# Altium schematic sheet POWER.SchDoc(POWER)
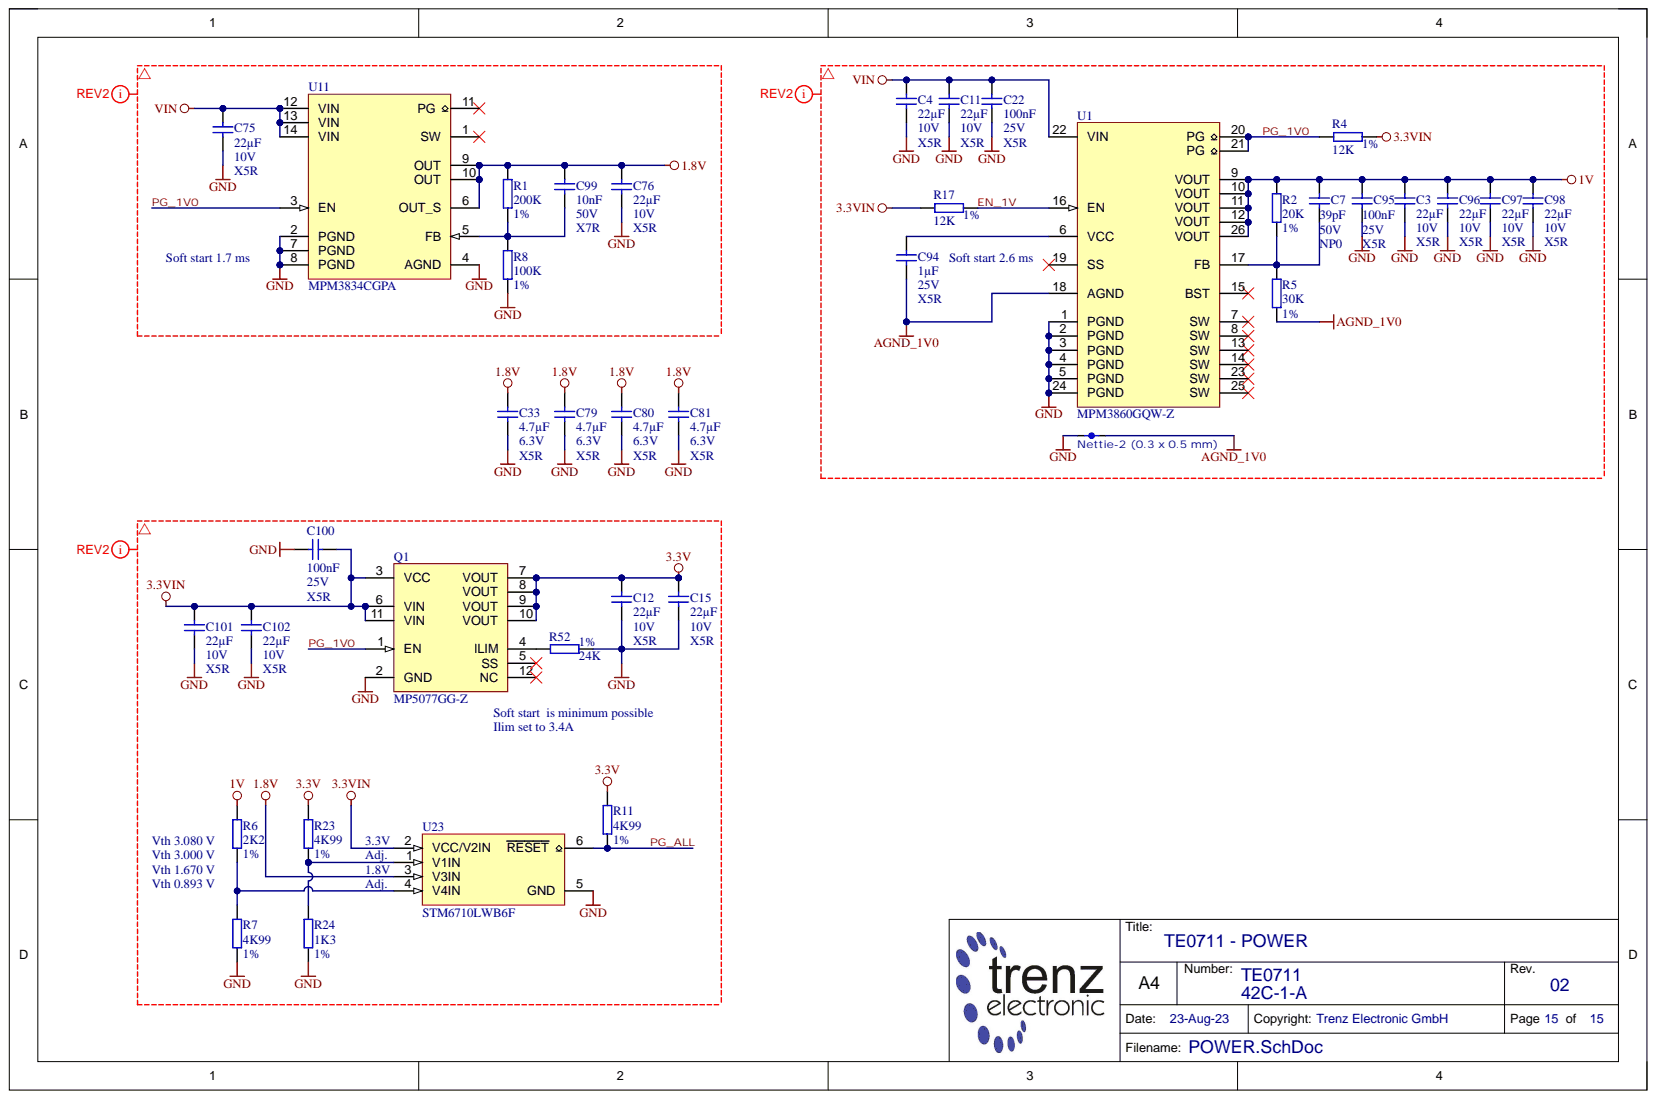
[diagram p0: POWER.SchDoc]

## Parts
C3  22µF  Technology: X5R  Voltage: 10V  [CAPC1608X90N_V]
C4  22µF  Technology: X5R  Voltage: 10V  [CAPC1608X90N_V]
C7  39pF  Technology: NP0  Voltage: 50V  [CAPC1005X55N_V]
C11  22µF  Technology: X5R  Voltage: 10V  [CAPC1608X90N_V]
C12  22µF  Technology: X5R  Voltage: 10V  [CAPC1608X90N_V]
C15  22µF  Technology: X5R  Voltage: 10V  [CAPC1608X90N_V]
C22  100nF  Technology: X5R  Voltage: 25V  [CAPC1005X55N_V]
C33  4.7µF  Technology: X5R  Voltage: 6.3V  [CAPC1005X55N_V]
C75  22µF  Technology: X5R  Voltage: 10V  [CAPC1608X90N_V]
C76  22µF  Technology: X5R  Voltage: 10V  [CAPC1608X90N_V]
C79  4.7µF  Technology: X5R  Voltage: 6.3V  [CAPC1005X55N_V]
C80  4.7µF  Technology: X5R  Voltage: 6.3V  [CAPC1005X55N_V]
C81  4.7µF  Technology: X5R  Voltage: 6.3V  [CAPC1005X55N_V]
C94  1µF  Technology: X5R  Voltage: 25V  [CAPC1005X55N_V]
C95  100nF  Technology: X5R  Voltage: 25V  [CAPC1005X55N_V]
C96  22µF  Technology: X5R  Voltage: 10V  [CAPC1608X90N_V]
C97  22µF  Technology: X5R  Voltage: 10V  [CAPC1608X90N_V]
C98  22µF  Technology: X5R  Voltage: 10V  [CAPC1608X90N_V]
C99  10nF  Technology: X7R  Voltage: 50V  [CAPC1005X55N_V]
C100  100nF  Voltage: 25V  [CAPC1005X55N_V]
C101  22µF  Technology: X5R  Voltage: 10V  [CAPC1608X90N_V]
C102  22µF  Technology: X5R  Voltage: 10V  [CAPC1608X90N_V]
Q1  MP5077GG-Z  [QFN40P200X200X100-12N]
R1  200K  Tolerance: 1%  [RESC1005X40N_V]
R2  20K  Tolerance: 1%  [RESC1005X40N_V]
R4  12K  Tolerance: 1%  [RESC1005X40N_V]
R5  30K  Tolerance: 1%  [RESC1005X40N_V]
R6  2K2  Tolerance: 1%  [RESC1005X40N_V]
R7  4K99  Tolerance: 1%  [RESC1005X40N_V]
R8  100K  Tolerance: 1%  [RESC1005X40N_V]
R11  4K99  Tolerance: 1%  [RESC1005X40N_V]
R17  12K  Tolerance: 1%  [RESC1005X40N_V]
R23  4K99  Tolerance: 1%  [RESC1005X40N_V]
R24  1K3  Tolerance: 1%  [RESC1005X40N_V]
R52  24K  Tolerance: 1%  [RESC1005X40N_V]
U1  MPM3860GQW-Z  [MPM3650]
U11  MPM3834CGPA  [MPM3834C]
U23  STM6710LWB6F  [SOT95P280X145-6N]
V1  Nettie-2 (0.3 x 0.5 mm)  [Nettie-2-Bar-0.3-0.5mm]

## Nets
1.8V: C33.1 C76.1 C79.1 C80.1 C81.1 C99.1 R1.1 U11.6(OUT_S) U11.9(OUT) U11.10(OUT) U23.3(V3IN)
1V: C3.1 C7.1 C95.1 C96.1 C97.1 C98.1 R2.1 R6.1 U1.9(VOUT) U1.10(VOUT) U1.11(VOUT) U1.12(VOUT) U1.26(VOUT)
3.3V: C12.1 C15.1 Q1.7(VOUT) Q1.8(VOUT) Q1.9(VOUT) Q1.10(VOUT) R11.1 R23.1
3.3VIN: C100.2 C101.1 C102.1 Q1.3(VCC) Q1.6(VIN) Q1.11(VIN) R4.2 R17.1 U23.2(VCC/V2IN)
AGND_1V0: C94.2 R5.2 U1.18(AGND) V1.2
GND: C3.2 C4.2 C11.2 C12.2 C15.2 C22.2 C33.2 C75.2 C76.2 C79.2 C80.2 C81.2 C95.2 C96.2 C97.2 C98.2 C100.1 C101.2 C102.2 Q1.2(GND) R7.2 R8.2 R24.2 R52.2 U1.1(PGND) U1.2(PGND) U1.3(PGND) U1.4(PGND) U1.5(PGND) U1.24(PGND) U11.2(PGND) U11.4(AGND) U11.7(PGND) U11.8(PGND) U23.5(GND) V1.1
VIN: C4.1 C11.1 C22.1 C75.1 U1.22(VIN) U11.12(VIN) U11.13(VIN) U11.14(VIN)
EN_1V: R17.2 U1.16(EN)
PG_1V0: Q1.1(EN) R4.1 U1.20(PG) U1.21(PG) U11.3(EN)
PG_ALL: R11.2 U23.6(RESET)
NetC7_2: C7.2 R2.2 R5.1 U1.17(FB)
NetC94_1: C94.1 U1.6(VCC)
NetC99_2: C99.2 R1.2 R8.1 U11.5(FB)
NetQ1_4: Q1.4(ILIM) R52.1
NetR6_2: R6.2 R7.1 U23.4(V4IN)
NetR23_2: R23.2 R24.1 U23.1(V1IN)

Not connected: Q1 5(SS) 12(NC); U1 7(SW) 8(SW) 13(SW) 14(SW) 15(BST) 19(SS) 23(SW) 25(SW); U11 1(SW) 11(PG)

## Library data of the parts
C3 C4 C11 C12 C15 C75 C76 C96-C98 C101 C102 = 30929: KON 22µF 10V X5R 20% SMD BF 1608 (0603); Database Table Name: C_1608_0603; Mixed: SMT; Temperature-Range: -55/+85; Value: 22u; AN: 30929; Case: 1608 (0603); Component Kind: Standard; Library Name: TE.DbLib; Tolerance: 20%
C7 = 23753: KON 39pF 50V NP0 SMD BF 1005 (0402); Database Table Name: C_1005_0402; Mixed: SMT; Value: 39p; AN: 23753; Case: 1005 (0402); Component Kind: Standard; Library Name: TE.DbLib
C22 C95 C100 = 22472: KON 100nF 25V X5R ±10% SMD BF 1005 (0402); Database Table Name: C_1005_0402; Mixed: SMT; Preferred: Yes; Temperature-Range: -55/+85; Value: 100n; AN: 22472; Case: 1005 (0402); Component Kind: Standard; Library Name: TE.DbLib
  C100: Technology: X5R
C33 C79-C81 = 24719: KON 4.7µF 6.3V X5R 20% SMD BF 1005 (0402); Database Table Name: C_1005_0402; Mixed: SMT; Preferred: Yes; Temperature-Range: -55/+85; Value: 4.7u; AN: 24719; Case: 1005 (0402); Component Kind: Standard; Library Name: TE.DbLib; Tolerance: 20%
C94 = 32544: KON 1µF 25V X5R 10% SMD BF 1005 (0402); Database Table Name: C_1005_0402; Mixed: SMT; Temperature-Range: -55/+85; Value: 1u; AN: 32544; Case: 1005 (0402); Component Kind: Standard; Library Name: TE.DbLib; Tolerance: 10%
C99 = 24705: KON 10nF 50V X7R 10% SMD BF 1005 (0402); Database Table Name: C_1005_0402; Mixed: SMT; Preferred: Yes; Temperature-Range: -55/+125; Value: 10n; AN: 24705; Case: 1005 (0402); Component Kind: Standard; Library Name: TE.DbLib; Tolerance: 10%
Q1 = 31912: MP5077GG-Z, Load Switch; Extra: 5.5V 7A, Power Switch/Driver 1:1 P-Channel 12-QFN (2x2); Mixed: SMT; Preferred: Yes; Temperature-Range: -40/+125 (Tj); AN: 31912; Case: QFN12; Component Kind: Standard; Database Table Name: SWITCH; H-ART: MP5077GG-Z; Library Name: TE.DbLib; Storage-Temperature: -65/+125 (Tj Abs); HelpURL: b:\AD\adlib\datasheet\MPS\MP5077\
R1 = 23846: WID SMD 200K 1% 0,063W  BF 1005 (0402); Database Table Name: R_1005_0402; H-ART: RC0402FR-07200KL; Mixed: SMT; Preferred: Yes; Voltage: 0.063W; AN: 23846; Case: 1005 (0402); Component Kind: Standard; Library Name: TE.DbLib; Technology: TK100; Value: 200K
R2 = 26460: WID SMD 20K 1% 0,063W BF 1005 (0402); Database Table Name: R_1005_0402; Mixed: SMT; Preferred: Yes; Voltage: 0.063W; AN: 26460; Case: 1005 (0402); Component Kind: Standard; Library Name: TE.DbLib; Technology: TK100; Value: 20K
R4 R17 = 22598: WID SMD 12K 1% 0,063W  BF 1005 (0402); Database Table Name: R_1005_0402; Mixed: SMT; Preferred: Yes; Voltage: 0.063W; AN: 22598; Case: 1005 (0402); Component Kind: Standard; Library Name: TE.DbLib; Technology: TK100; Value: 12K
R5 = 28770: WID SMD 30K 1% 0,063W  BF 1005 (0402); Database Table Name: R_1005_0402; Mixed: SMT; Voltage: 0.063W; AN: 28770; Case: 1005 (0402); Component Kind: Standard; Library Name: TE.DbLib; Technology: TK100; Value: 30K
R6 = 22748: WID SMD 2K2 1% 0,063W BF 1005 (0402); Database Table Name: R_1005_0402; H-ART: RC0402FR-132K2L; Mixed: SMT; Preferred: Yes; Value: 2.2K; Voltage: 0.063W; AN: 22748; Case: 1005 (0402); Component Kind: Standard; Library Name: TE.DbLib; Technology: TK100
R7 R11 R23 = 22754: WID SMD 4K99 1% 0,063W BF 1005 (0402); Database Table Name: R_1005_0402; H-ART: RC0402FR-074K99L; Mixed: SMT; Preferred: Yes; Value: 4.99K; Voltage: 0.063W; AN: 22754; Case: 1005 (0402); Component Kind: Standard; Library Name: TE.DbLib; Technology: TK100
R8 = 22558: WID SMD 100K 1% 0,063W  BF 1005 (0402); Database Table Name: R_1005_0402; H-ART: RC0402FR-13100KL; Mixed: SMT; Preferred: Yes; Voltage: 0.063W; AN: 22558; Case: 1005 (0402); Component Kind: Standard; Library Name: TE.DbLib; Technology: TK100; Value: 100K
R24 = 25471: WID SMD 1K3 1% 0,063W  BF 1005 (0402); Database Table Name: R_1005_0402; Mixed: SMT; Value: 1.3K; Voltage: 0.063W; AN: 25471; Case: 1005 (0402); Component Kind: Standard; Library Name: TE.DbLib; Technology: TK100
R52 = 22747: WID SMD 24K 1% 0,063W  BF 1005 (0402); Database Table Name: R_1005_0402; Mixed: SMT; Voltage: 0.063W; AN: 22747; Case: 1005 (0402); Component Kind: Standard; Library Name: TE.DbLib; Technology: TK100; Value: 24K
U1 = 31725: MPM3860GQW-Z, Step-Down Power Module; Database Table Name: DCDC; Extra: 7V 6A Non-Isolated PoL Module DC DC Converter 1 Output 24-QFN (4x6); Mixed: SMT; Preferred: Yes; Temperature-Range: -40/+125 (Tj); AN: 31725; Case: QFN24; Component Kind: Standard; H-ART: MPM3860GQW-Z; Library Name: TE.DbLib; Storage-Temperature: -65/+125 (Abs); HelpURL: b:\AD\adlib\datasheet\MPS\MPM3860\
U11 = 31749: MPM3834CGPA, Buck Switching Regulator IC; Database Table Name: DCDC; Extra: 6V 3A, Buck Switching Regulator IC Positive Adjustable 0.6V ECLGA-14 (2.5x2.5); H-ART: MPM3834CGPA-Z; Mixed: SMT; Preferred: Yes; Temperature-Range: -40/+125 (Tj); AN: 31749; Case: ECLGA14; Component Kind: Standard; Library Name: TE.DbLib; Storage-Temperature: -65/+125 (Tj Abs); HelpURL: b:\AD\adlib\datasheet\MPS\MPM3834C\
U23 = 32696: STM6710LWB6F, Multi-Voltage Supervisor; Extra: Supervisor Open Collector 4 Channel SOT-23-6; Mixed: SMT; Temperature-Range: -40/+85; Trenz-Stock: Yes; AN: 32696; Case: SOT23-6; Component Kind: Standard; Database Table Name: SUPERVISOR; H-ART: STM6710LWB6F; Library Name: TE.DbLib; Storage-Temperature: -55/+150 (Abs); HelpURL: b:\AD\adlib\datasheet\ST\STM67x0\
V1 = 30517: Database Table Name: PCB; AN: 30517; Component Kind: Net Tie; Description: Nettie-2 (0.3 x 0.5 mm); Library Name: TE.DbLib
